# Altium schematic sheet SH2 - CONNECTORS.SchDoc
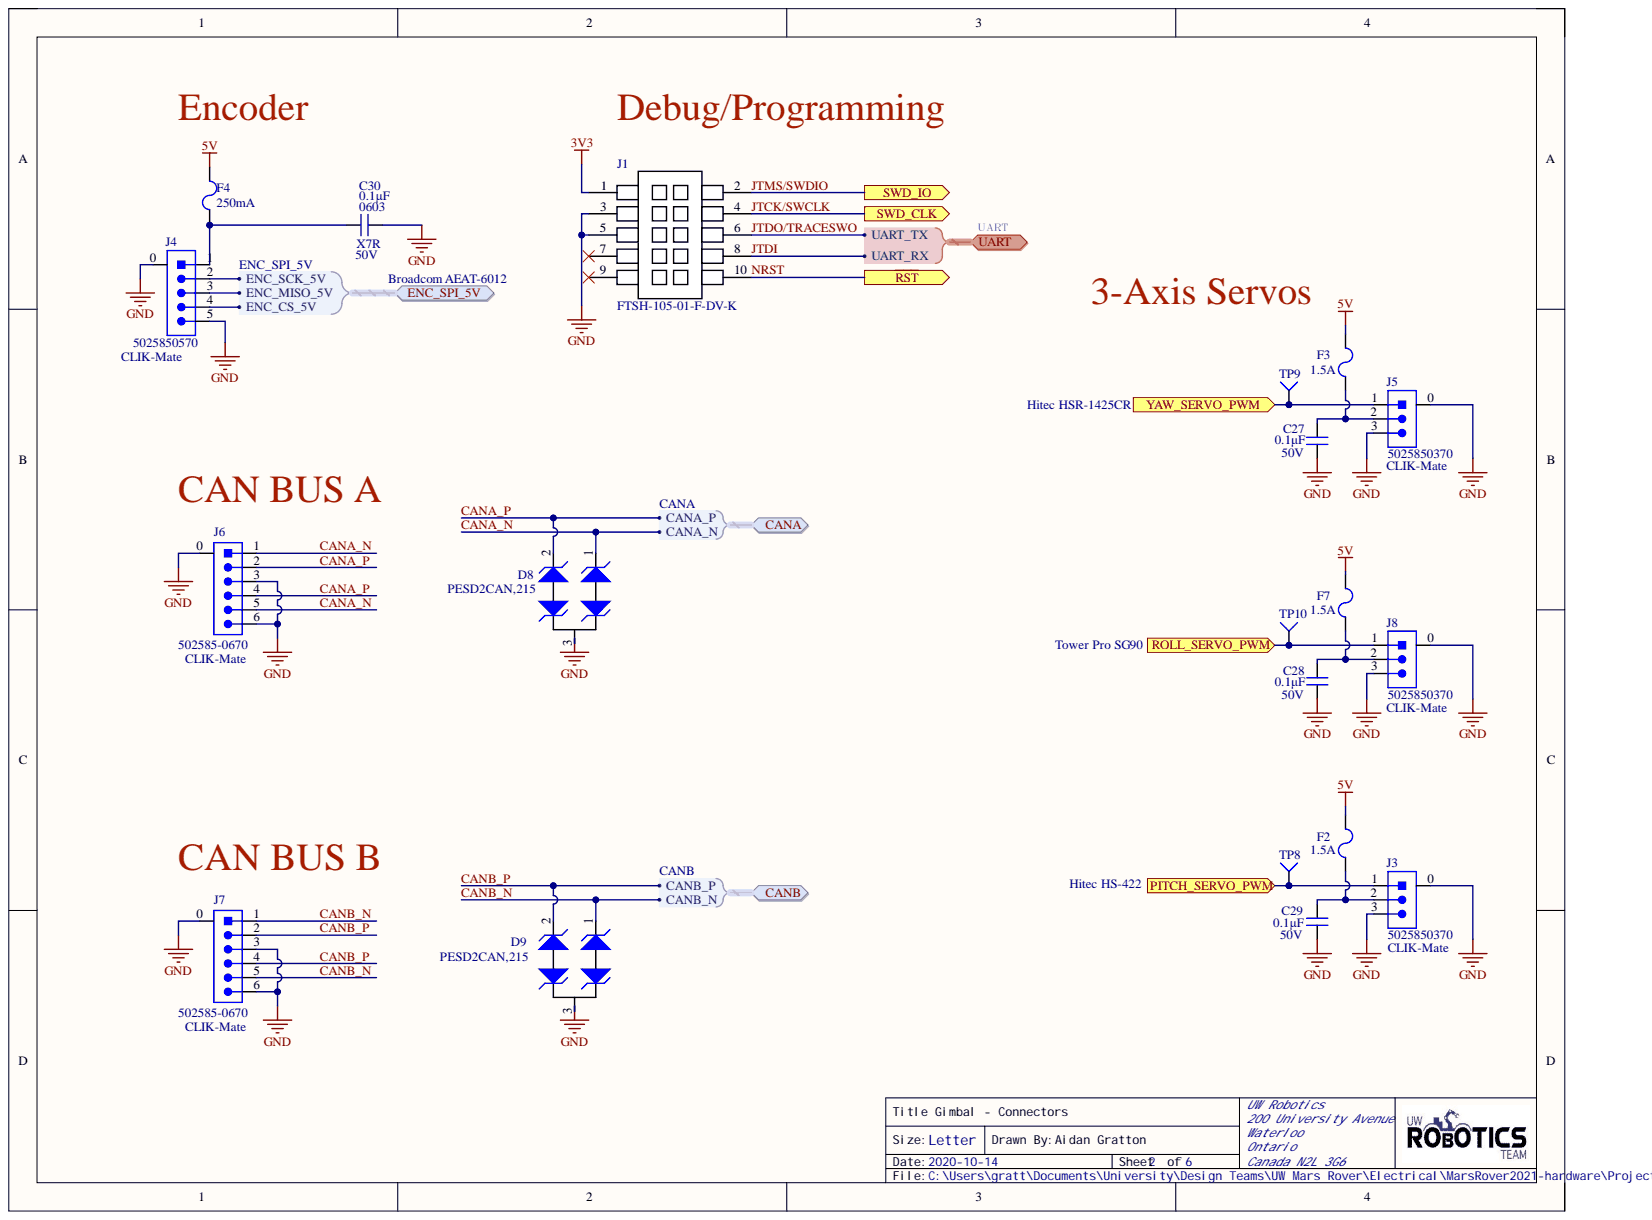
[diagram p0: SH2 - CONNECTORS.SchDoc]

## Parts
C27  value 0.1μF  Voltage Rating: 50V  [CAP0603]  (hidden comment: CAP_0.1uF_50V_0603)
C28  value 0.1μF  Voltage Rating: 50V  [CAP0603]  (hidden comment: CAP_0.1uF_50V_0603)
C29  value 0.1μF  Voltage Rating: 50V  [CAP0603]  (hidden comment: CAP_0.1uF_50V_0603)
C30  value 0.1μF  Case Code (Imperial): 0603  Dielectric: X7R  Voltage Rating: 50V  [CAP0603]  (hidden comment: CAP_0.1uF_50V_0603)
D8  Manufacturer Part Number 1: PESD2CAN,215  [TVS_DIODE_CAN]  (hidden comment: TVS_DIODE_CAN)
D9  Manufacturer Part Number 1: PESD2CAN,215  [TVS_DIODE_CAN]  (hidden comment: TVS_DIODE_CAN)
F2  Current: 1.5A  [FUSE_1206]  (hidden comment: FUSE_1.5A_1206)
F3  Current: 1.5A  [FUSE_1206]  (hidden comment: FUSE_1.5A_1206)
F4  Current Rating: 250mA  [FUSE_0603]  (hidden comment: FUSE_250mA_0603)
F7  Current: 1.5A  [FUSE_1206]  (hidden comment: FUSE_1.5A_1206)
J1  Manufacturer Part Number 1: FTSH-105-01-F-DV-K  [FTSH-105-01-F-DV-K-P-TR]  (hidden comment: CON_PROGRAMMING)
J3  Family: CLIK-Mate  Manufacturer Part Number 1: 5025850370  [MOLEX-5025850370]  (hidden comment: CON_3M_SM_RA)
J4  Family: CLIK-Mate  Manufacturer Part Number 1: 5025850570  [MOLEX-5025850570]  (hidden comment: CON_5M_SM_RA)
J5  Family: CLIK-Mate  Manufacturer Part Number 1: 5025850370  [MOLEX-5025850370]  (hidden comment: CON_3M_SM_RA)
J6  Family: CLIK-Mate  Manufactor Number: 502585-0670  [MOLEX-5025850670]  (hidden comment: CON_6M_SM_RA_1.5mm)
J7  Family: CLIK-Mate  Manufactor Number: 502585-0670  [MOLEX-5025850670]  (hidden comment: CON_6M_SM_RA_1.5mm)
J8  Family: CLIK-Mate  Manufacturer Part Number 1: 5025850370  [MOLEX-5025850370]  (hidden comment: CON_3M_SM_RA)
TP8  TESTPOINT_1MM_CIRCLE  [TESTPOINT_CIRCLE_1MM]  (value not drawn)
TP9  TESTPOINT_1MM_CIRCLE  [TESTPOINT_CIRCLE_1MM]  (value not drawn)
TP10  TESTPOINT_1MM_CIRCLE  [TESTPOINT_CIRCLE_1MM]  (value not drawn)

## Ports
CANA, CANA.CANA_N, CANA.CANA_P, CANB, CANB.CANB_N, CANB.CANB_P, ENC_SPI_5V, ENC_SPI_5V.ENC_CS_5V, ENC_SPI_5V.ENC_MISO_5V, ENC_SPI_5V.ENC_SCK_5V, PITCH_SERVO_PWM, ROLL_SERVO_PWM, SWD_CLK, SWD_IO, UART, UART.UART_RX, UART.UART_TX, YAW_SERVO_PWM, ~{RST}

## Nets
3V3: J1.1
5V: F2.2 F3.2 F4.2 F7.2
GND: C27.1 C28.1 C29.1 C30.1 D8.3 D9.3 J1.3 J1.5 J3.0 J3.3 J4.0 J4.5 J5.0 J5.3 J6.0 J6.3 J6.6 J7.0 J7.3 J7.6 J8.0 J8.3
CANA_N: D8.1 J6.1 J6.5 ; port CANA
CANA_P: D8.2 J6.2 J6.4 ; port CANA
CANB_N: D9.1 J7.1 J7.5 ; port CANB
CANB_P: D9.2 J7.2 J7.4 ; port CANB
ENC_SPI_5V.ENC_CS_5V: J4.4 ; port ENC_SPI_5V
ENC_SPI_5V.ENC_MISO_5V: J4.3 ; port ENC_SPI_5V
ENC_SPI_5V.ENC_SCK_5V: J4.2 ; port ENC_SPI_5V
JTCK/SWCLK: J1.4 ; port SWD_CLK
JTDI: J1.8 ; port UART
JTDO/TRACESWO: J1.6 ; port UART
JTMS/SWDIO: J1.2 ; port SWD_IO
NRST: J1.10 ; port ~{RST}
PITCH_SERVO_PWM: J3.1 TP8.1 ; port PITCH_SERVO_PWM
ROLL_SERVO_PWM: J8.1 TP10.1 ; port ROLL_SERVO_PWM
YAW_SERVO_PWM: J5.1 TP9.1 ; port YAW_SERVO_PWM
NetC27_2: C27.2 F3.1 J5.2
NetC28_2: C28.2 F7.1 J8.2
NetC29_2: C29.2 F2.1 J3.2
NetC30_2: C30.2 F4.1 J4.1

Not connected: J1 7 9

## Library data of the parts
C27-C30 = CAP_0.1uF_50V_0603: CAP CER 0.1UF 50V X7R 0603; Manufacturer 1: Yageo; Manufacturer Part Number 1: CC0603KRX7R9BB104; Case Code (Metric): 1608; Component Kind: Standard; Dimensions: 0.063" L x 0.031" W (1.60mm x 0.80mm); Mount: Surface Mount; Package: 0603 (1608 Metric); Signal Integrity: Cap; Simulation: CAP; Supplier 1: Digi-Key; Supplier Part Number 1: 311-1344-1-ND; Temperature Coefficient: X7R; Library Name: UWRT_Schematic_Library_2020.SchLib
  C27-C29: Case Code (Imperial): 0603; Dielectric: X7R
D8 D9 = TVS_DIODE_CAN: TVS DIODE 24V 41V SOT323-3; Manufacturer 1: Nexperia USA Inc.; Component Kind: Standard; Mount: Surface Mount; Package: SC-70, SOT-323; Supplier 1: Digi-Key; Supplier Part Number 1: 1727-3891-1-ND; Library Name: UWRT_Schematic_Library_2020.SchLib
F2 F3 F7 = FUSE_1.5A_1206: FUSE SMD 1.5A 63V; Manufacturer 1: Littelfuse; Manufacturer Part Number 1: 046601.5NR; Approvals: UL,CSA; DC Cold Resistance: 31mR; Fuse Type: Board Mount (Cartridge Style Excluded); Power Dissipation: 157.5mW; Case Code (Imperial): 1206; Case Code (Metric): 3216; Case/Package: 1206; Component Kind: Standard; Current Rating: 1.5A; Depth: 1.52mm; Height: 0.023inch; Housing Material: Ceramic; Length: 3.18mm; Max Operating Temperature: 90°C; Min Operating Temperature: -55°C; Mount: Surface Mount; Supplier 1: Digi-Key; Supplier Part Number 1: F1462CT-ND; Voltage Rating (AC): 63V; Voltage Rating (DC): 63V; Width: 0.06inch; Library Name: UWRT_Schematic_Library_2020.SchLib
F4 = FUSE_250mA_0603: FUSE SMD 250mA 32V; Manufacturer 1: Littelfuse; Manufacturer Part Number 1: 0467.250NRHF; Response Time: Fast Blow; Component Kind: Standard; Mount: Surface Mount; Supplier 1: Digi-Key; Supplier Packaging 1: Cut Tape; Supplier Part Number 1: F6020CT-ND; Voltage Rating (AC): 32V; Voltage Rating (DC): 32V; Library Name: UWRT_Schematic_Library_2020.SchLib
J1 = CON_PROGRAMMING: Board Connector, 10 Contact(s), 2 Row(s), Male, Straight, 0.05 inch Pitch, Surface Mount Terminal, Locking; Manufacturer 1: Samtec; Connector Type: Male Pin; Max Current Rating: 1.75A; Number of Contacts: 10; Pitch: 1.27mm; Category: Headers and Wire Housings; Color: Black; Component Kind: Standard; ComponentLink1Description: https://datasheet.ciiva.com:443/pdfs/VipMasterIC/IC/SAMI/SAMI-S-A0003531380/SAMI-S-A0003531380-1.pdf?src-supplier=IHS+Markit; ComponentLink1URL: https://datasheet.ciiva.com:443/pdfs/VipMasterIC/IC/SAMI/SAMI-S-A0003531380/SAMI-S-A0003531380-1.pdf?src-supplier=IHS+Markit; ComponentLink2Description: https://datasheet.ciiva.com/19461/2046846-19461026.pdf?src-supplier=Jameco; ComponentLink2URL: https://datasheet.ciiva.com/19461/2046846-19461026.pdf?src-supplier=Jameco; ComponentLink3Description: https://datasheet.ciiva.com/16657/ftsh-mt-16657371.pdf?src-supplier=Avnet+Europe; ComponentLink3URL: https://datasheet.ciiva.com/16657/ftsh-mt-16657371.pdf?src-supplier=Avnet+Europe; ComponentLink4Description: https://datasheet.ciiva.com/15195/getdatasheetpartid-133463-15195260.pdf?src-supplier=Verical; ComponentLink4URL: https://datasheet.ciiva.com/15195/getdatasheetpartid-133463-15195260.pdf?src-supplier=Verical; ComponentLink5Description: https://datasheet.ciiva.com/738/ftsh-1xx-xx-xxx-dv-xxx-mkt-738998.pdf?src-supplier=Digi-Key; ComponentLink5URL: https://datasheet.ciiva.com/738/ftsh-1xx-xx-xxx-dv-xxx-mkt-738998.pdf?src-supplier=Digi-Key; ComponentLink6Description: https://datasheet.ciiva.com/10245/ftsh-mt-10245273.pdf?src-supplier=Avnet; ComponentLink6URL: https://datasheet.ciiva.com/10245/ftsh-mt-10245273.pdf?src-supplier=Avnet; Contact Material: Copper,Bronze; Contact Mating Length: 0.12inch; Contact Plating: Gold; Depth: 3.43mm; Height: 3.3mm; Housing Color: Black; Housing Material: Polymer; Lead Free: Lead Free; Length: 15.2mm; Max Operating Temperature: 125°C; Min Operating Temperature: -55°C; Mount: Surface Mount; Number of Positions: 10; Number of Rows: 2; Orientation: Straight; Package Quantity: 1; Packaging: Rail/Tube; Pricing 1: 1=2.90000, 10=2.78400, 100=2.32000, 500=1.97200, 1000=1.68200, 5000=1.50800 (USD); Radiation Hardening: No; RoHS: Yes; Row Spacing: 0.05inch; Stock 1: 19926; Supplier 1: Digi-Key; Supplier Part Number 1: SAM8796-ND; Termination: Solder; Library Name: UWRT_Schematic_Library_2020.SchLib
J3 J5 J8 = CON_3M_SM_RA: CONN RCPT 3POS 0.059 TIN SMD R/A; Manufacturer 1: Molex; Connector Type: Receptacle,Center Strip Contact; Contact Resistance: 20mR; Current Rating: 2A; Gender: Female; Glow Wire Compliant: Non-compliant; Number of Circuits: 3; Pitch: 1.5mm; Voltage Rating: 100V; Category: Headers and Wire Housings; Color: Beige; Component Kind: Standard; Contact Gender: Female; Contact Material: Copper Alloy; Contact Plating: Tin; Depth: 7.3mm; Fastening Type: Latch; Housing Color: Beige; Housing Material: Thermoplastic; Length: 8.8mm; Max Operating Temperature: 85°C; Min Operating Temperature: -40°C; Mount: Surface Mount; Number of Contacts: 3; Number of Positions: 3; Number of Rows: 1; Orientation: Right Angle; Pricing 2: 1=0.53000, 10=0.50100, 100=0.38380, 500=0.33374 (USD); REACH SVHC: No SVHC; Stock 2: 133; Supplier 1: Digi-Key; Supplier Part Number 1: WM12831CT-ND; Termination: Solder; Library Name: UWRT_Schematic_Library_2020.SchLib
J4 = CON_5M_SM_RA: CONN HEADER SMD RIGHT ANGLE 1.5MM PITCH; Manufacturer 1: Molex; Connector Type: Receptacle,Center Strip Contact; Current Rating: 2A; Number of Circuits: 5; Component Kind: Standard; Mount: Surface Mount; Number of Contacts: 5; Number of Positions: 5; Number of Rows: 1; Orientation: Right Angle; Supplier 1: Digi-Key; Supplier Part Number 1: WM14469CT-ND; Termination: Solder; Library Name: UWRT_Schematic_Library_2020.SchLib
J6 J7 = CON_6M_SM_RA_1.5mm: CONN HEADER SMD RIGHT ANGLE 1.5 MM PITCH; Manufacturer: Molex; Component Kind: Standard; Supplier 1: Digi-Key; Supplier Part Number 1: WM12832CT-ND; Library Name: UWRT_Schematic_Library_2020.SchLib
TP8-TP10 = TESTPOINT_1MM_CIRCLE: TESTPOINT 1MM CIRCLE; Component Kind: Standard; Library Name: UWRT_Schematic_Library_2020.SchLib
